# Altium schematic sheet MOSFETs.SchDoc
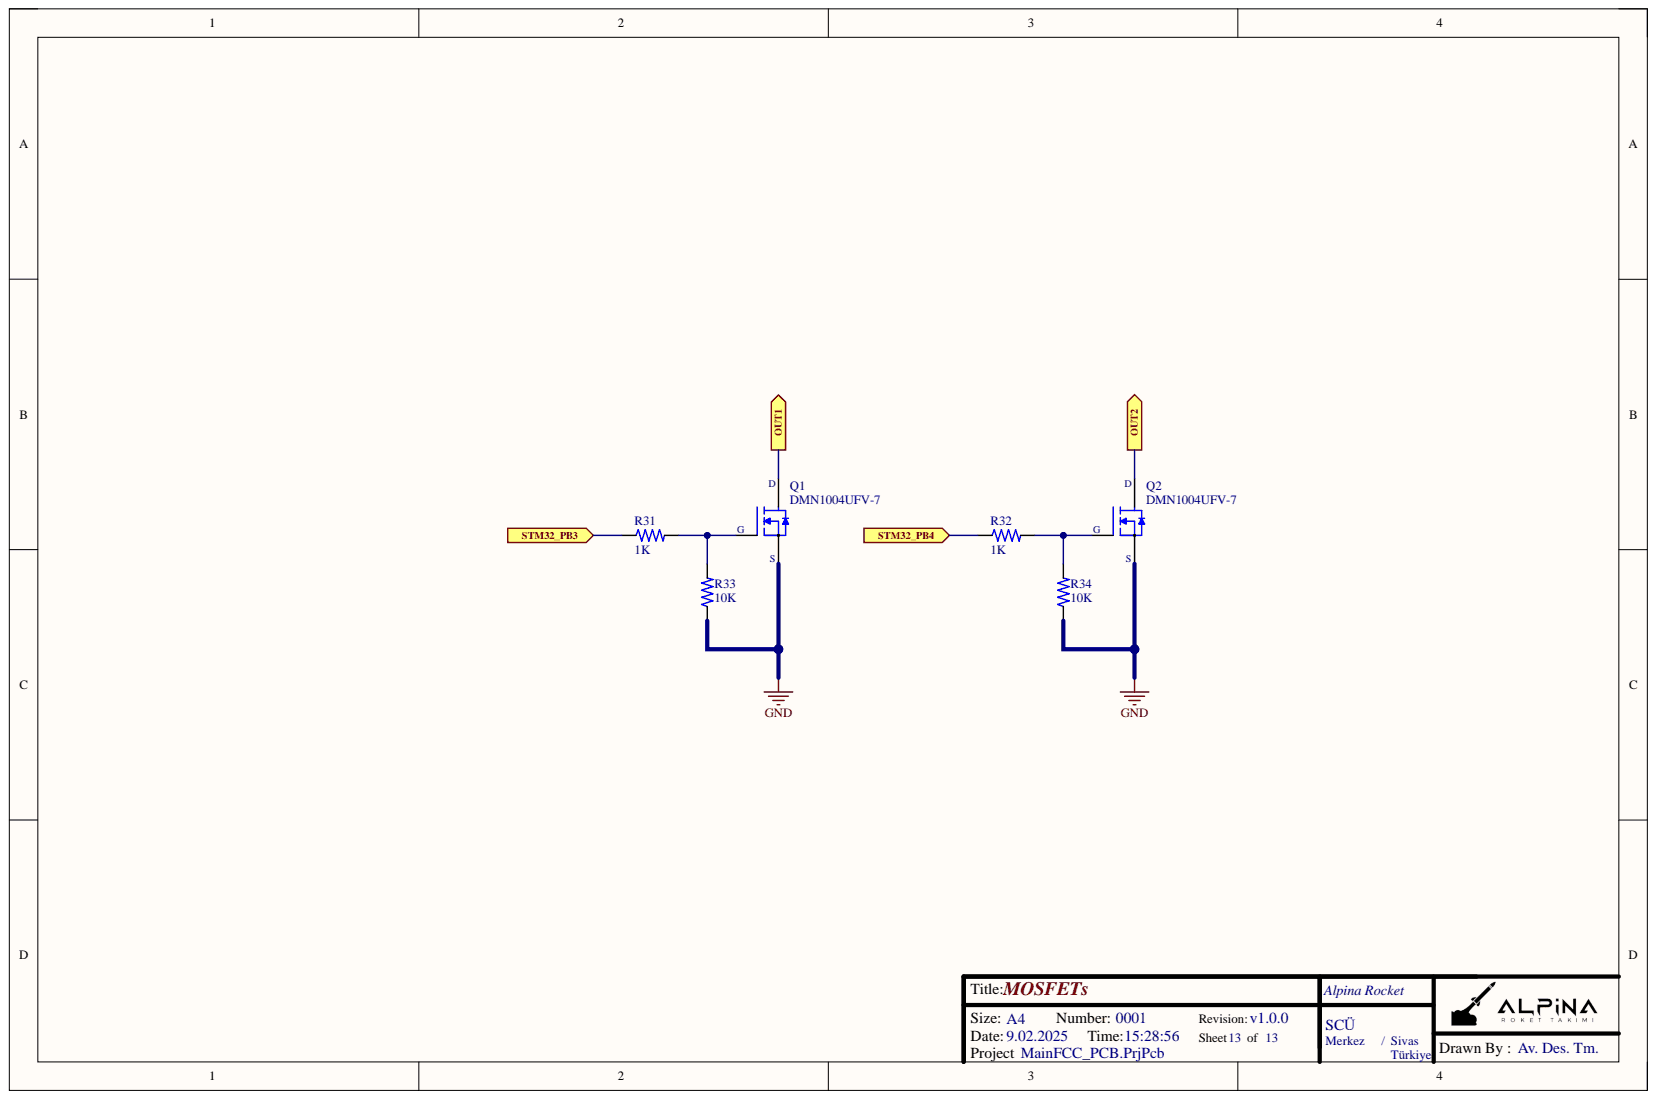
[diagram p0: MOSFETs.SchDoc]

## Parts
Q1  DMN1004UFV-7  [MergenElektronik_Transistors_Mosfets_8-PowerVDFN]
Q2  DMN1004UFV-7  [MergenElektronik_Transistors_Mosfets_8-PowerVDFN]
R31  1K  [MergenElektronik_Resistors_ChipResistors_0603_M_NS]
R32  1K  [MergenElektronik_Resistors_ChipResistors_0603_M_NS]
R33  10K  [MergenElektronik_Resistors_ChipResistors_0603_M_NS]
R34  10K  [MergenElektronik_Resistors_ChipResistors_0603_M_NS]

## Ports
OUT1, OUT2, STM32_PB3, STM32_PB4

## Nets
GND: Q1.2, 3, 4 Q2.2, 3, 4 R33.1 R34.1
OUT1: Q1.5 ; port OUT1
OUT2: Q2.5 ; port OUT2
STM32_PB3: R31.1 ; port STM32_PB3
STM32_PB4: R32.1 ; port STM32_PB4
NetQ1_1: Q1.1 R31.2 R33.2
NetQ2_1: Q2.1 R32.2 R34.2

## Library data of the parts
Q1 Q2 = MergenElektronik_Transistors_Mosfets_DMN1004UFV-7: Mergen Elektronik - Transistors - Mosfets - DMN1004UFV-7; Manufacturer: onsemi; Current Rating: 70A; Model: Mosfet; Vdss: 12V; Component Kind: Standard; Digi-Key Number: DMN1004UFV-7DITR-ND; Farnell Number: 3518380; Library Name: MergenElektronik_Transistors_Mosfets.SchLib; Manufacturer Number: DMN1004UFV-7; Mounting Type: Surface Mount; Mouser Number: 621-DMN1004UFV-7; Package: 8-PowerVDFN; Rating Current: 70A; Supplier 1: Digi-Key; Supplier 2: Mouser; Supplier Part Number 1: DMN1004UFV-7; Supplier Part Number 2: 621-DMN1004UFV-7; Temperature: -55°C up to +150°C (TJ); Value: DMN1004UFV-7; Voltage Rating: 12V; Id: 70A
R31 R32 = MergenElektronik_Resistors_ChipResistors_0603_1K: Mergen Elektronik - Resistors - Chip Resistors - 0603 - 1K; Manufacturer: Stackpole Electronics Inc; Manufacturer Number: RMCF0603JT1K00; Power: 1/10W; Tolerance: ±5%; Value: Chip Resistor - 0603 - 1K; Component Kind: Standard; Digi-Key Number: RMCF0603JT1K00CT-ND; Library Name: MergenElektronik_Resistors_ChipResistors.IntLib; Model: Chip Resistor; Mounting Type: Surface Mount; Mouser Number: 708-RMCF0603JT1K00; Package: 0603(1608); Supplier 1: Digikey; Supplier 2: Mouser; Supplier Part Number 1: RMCF0603JT1K00DKR-ND; Supplier Part Number 2: 708-RMCF0603JT1K00; Temperature: -55°C up to +155°C - X8R
R33 R34 = MergenElektronik_Resistors_ChipResistors_0603_10K: Mergen Elektronik - Resistors - Chip Resistors - 0603 - 10K; Manufacturer: Stackpole Electronics Inc; Manufacturer Number: RMCF0603JT10K0; Power: 1/10W; Tolerance: ±5%; Value: Chip Resistor - 0603 - 10K; Component Kind: Standard; Digi-Key Number: RMCF0603JT10K0CT-ND; Library Name: MergenElektronik_Resistors_ChipResistors.IntLib; Model: Chip Resistor; Mounting Type: Surface Mount; Package: 0603(1608); Supplier 1: Digi-Key; Supplier Part Number 1: RMCF0603JT10K0-ND; Temperature: -55°C up to +155°C - X8R
